# Altium schematic sheet PWR.SchDoc
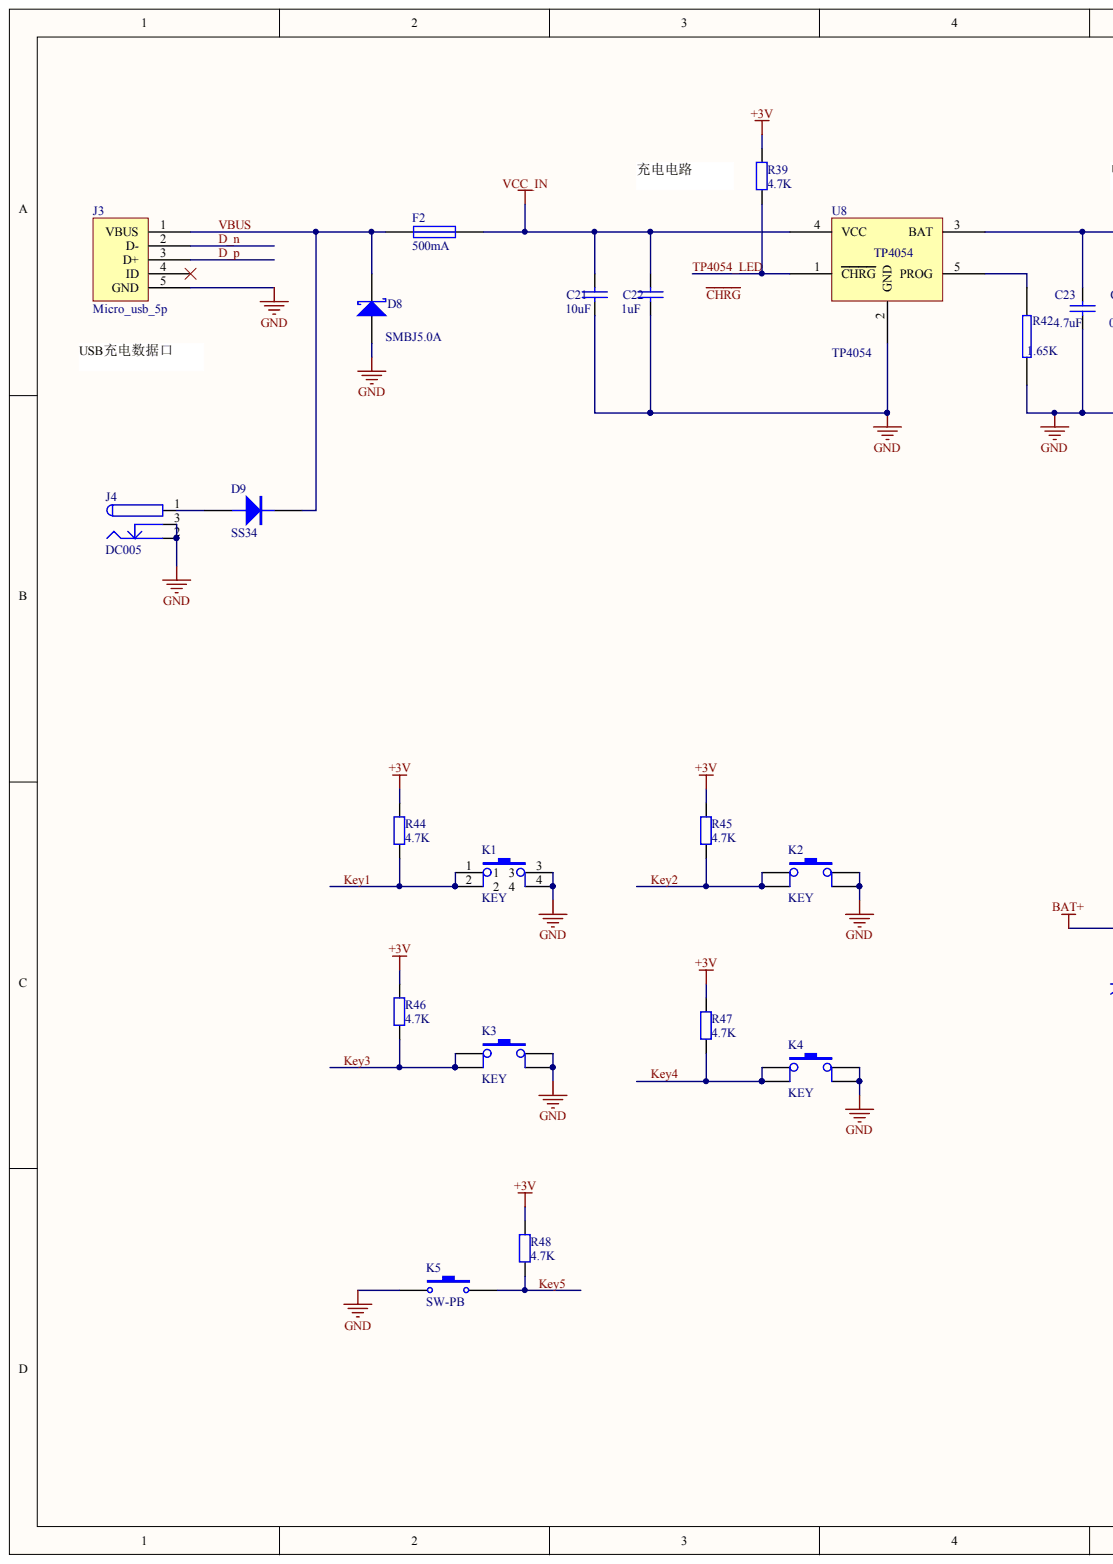
[diagram p0: PWR.SchDoc, left]
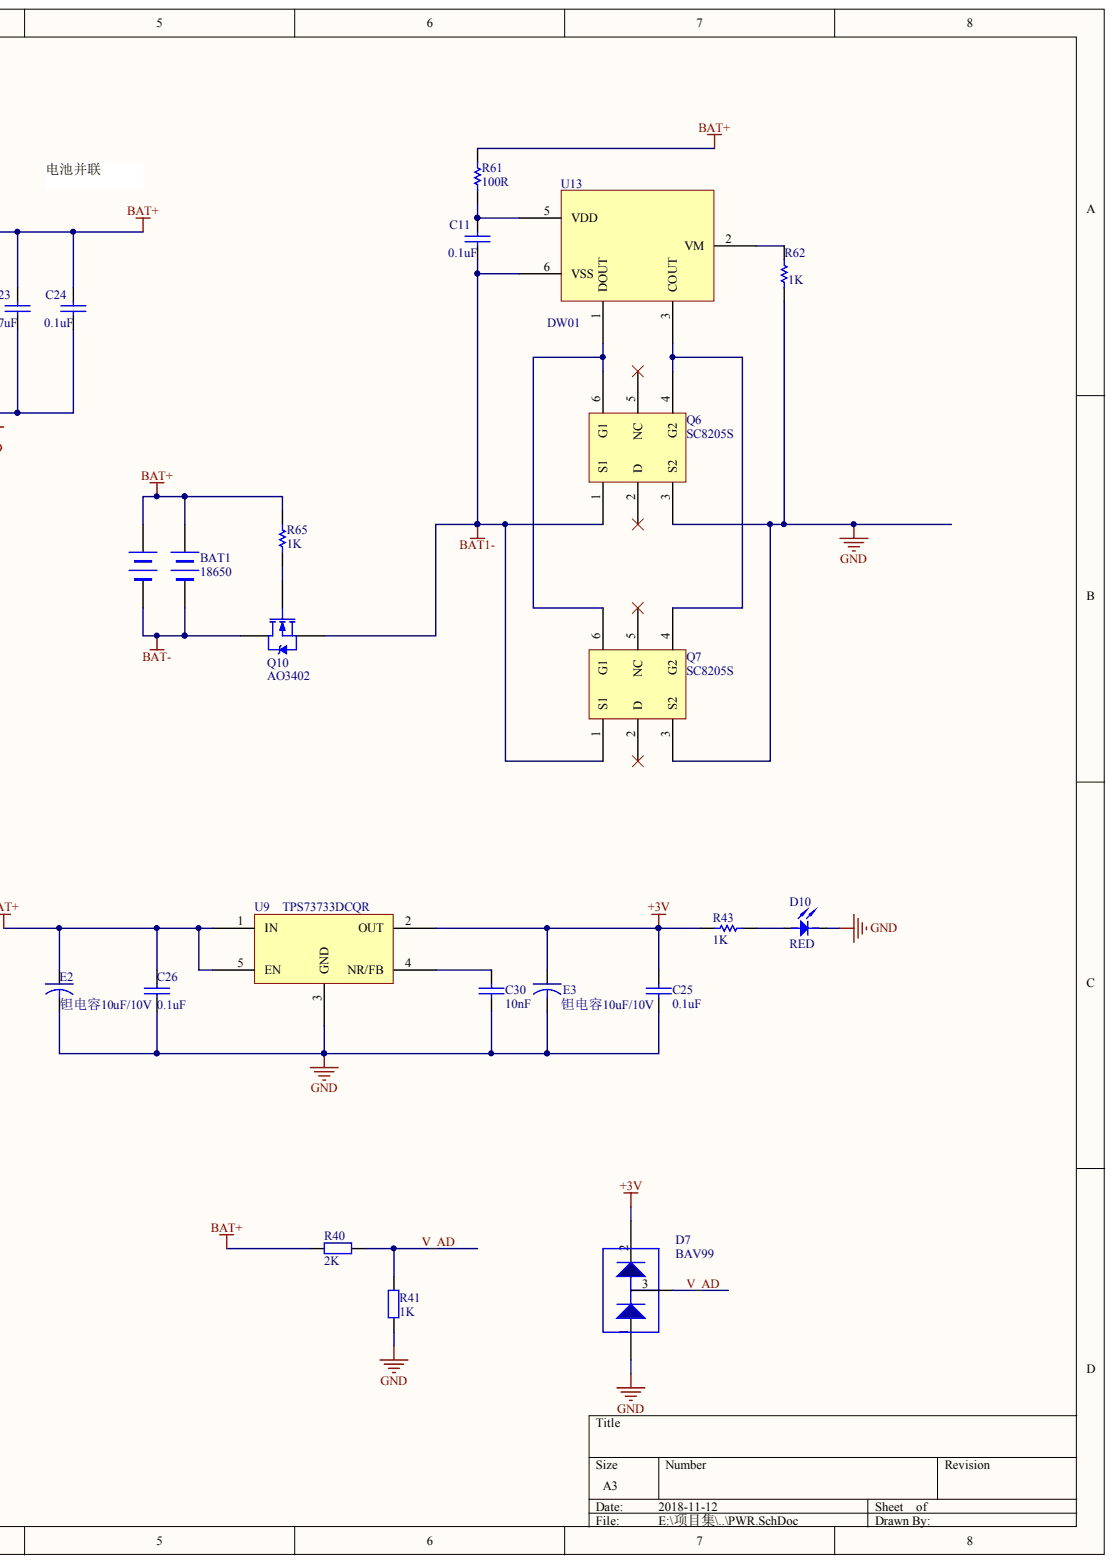
[diagram p1: PWR.SchDoc, right]

## Parts
BAT1  18650  [TANPIAN]
C11  0.1uF  [0603C]
C21  10uF  [0805C]
C22  1uF  [0603C]
C23  4.7uF  [0603C]
C24  0.1uF  [0603C]
C25  0.1uF  [0603C]
C26  0.1uF  [0603C]
C30  10nF  [0603C]
D7  BAV99  [SOT-23]
D8  SMBJ5.0A  [SMB]
D9  SS34  [SMA]
D10  RED  [FDCASE0603]
E2  钽电容10uF/10V  [3216]
E3  钽电容10uF/10V  [3216]
F2  500mA  [0805-L]
J3  Micro_usb_5p  [micro usb SH]
J4  DC005  [DC-005A]
K1  KEY  [4.5*4.5*4.3]
K2  KEY  [4.5*4.5*4.3]
K3  KEY  [4.5*4.5*4.3]
K4  KEY  [4.5*4.5*4.3]
K5  SW-PB  [C_6*6]
Q6  SC8205S  [SOT-23-6L]
Q7  SC8205S  [SOT-23-6L]
Q10  AO3402  [SOT-23]
R39  4.7K  [0603R]
R40  2K  [0603R]
R41  1K  [0603R]
R42  1.65K  [0603R]
R43  1K  [0603R]
R44  4.7K  [0603R]
R45  4.7K  [0603R]
R46  4.7K  [0603R]
R47  4.7K  [0603R]
R48  4.7K  [0603R]
R61  100R  [0603R]
R62  1K  [0603R]
R65  1K  [0603R]
U8  TP4054  [SOT-23-5L]
U9  TPS73733DCQR  [SOT223-6]
U13  DW01  [SOT-23-6L]

## Nets
+3V: C25.2 D7.2 E3.1 R39.2 R43.2 R44.2 R45.2 R46.2 R47.2 R48.2 U9.2(OUT)
BAT1-: C11.2 Q6.1(S1) Q7.1(S1) Q10.2 U13.6(VSS)
BAT+: BAT1.1 BAT1.3 C23.1 C24.1 C26.1 E2.1 R40.1 R61.1 R65.1 U8.3(BAT) U9.1(IN) U9.5(EN)
BAT-: BAT1.2 BAT1.4 Q10.3
GND: C21.2 C22.2 C23.2 C24.2 C25.1 C26.2 C30.1 D7.1 D8.1 D10.K E2.2 E3.2 J3.5(GND) J4.2 J4.3 K1.3 K1.4 K2.3 K2.4 K3.3 K3.4 K4.3 K4.4 K5.1 Q6.3(S2) Q7.3(S2) R41.1 R42.1 R62.2 U8.2(GND) U9.3(GND)
VCC_IN: C21.1 C22.1 F2.2 U8.4(VCC)
D_N: J3.2(D-)
D_P: J3.3(D+)
KEY1: K1.1 K1.2 R44.1
KEY2: K2.1 K2.2 R45.1
KEY3: K3.1 K3.2 R46.1
KEY4: K4.1 K4.2 R47.1
KEY5: K5.2 R48.1
TP4054_LED: R39.1 U8.1(CHRG)
V_AD: D7.3 R40.2 R41.2
VBUS: D8.2 D9.2 F2.1 J3.1(VBUS)
NetC11_1: C11.1 R61.2 U13.5(VDD)
NetC30_2: C30.2 U9.4(NR/FB)
NetD9_1: D9.1 J4.1
NetD10_A: D10.A R43.1
NetQ6_4: Q6.4(G2) Q7.4(G2) U13.3(COUT)
NetQ6_6: Q6.6(G1) Q7.6(G1) U13.1(DOUT)
NetQ10_1: Q10.1 R65.2
NetR42_2: R42.2 U8.5(PROG)
NetR62_1: R62.1 U13.2(VM)
~{CHRG}:

Not connected: J3 4(ID); Q6 2(D) 5(NC); Q7 2(D) 5(NC)

## Library data of the parts
BAT1 = BATTERXH1.25: Component Kind: Standard; Library Name: My Devices.SCHLIB
C11 C21-C26 C30 = CAP: Component Kind: Standard
D7 = D-DIODE: Component Kind: Standard; Library Name: My Devices.SCHLIB
D8 = D Schottky: Schottky Diode; Code_JEDEC: DO-214-AA; Component Kind: Standard; LatestRevisionDate: 17-Jul-2002; LatestRevisionNote: Re-released for DXP Platform.; Library Name: Miscellaneous Devices.IntLib; PackageReference: SMB; Published: 8-Jun-2000; Simulation: SKYDIODE; Publisher: Altium Limited
D9 = DIODE: Component Kind: Standard; Library Name: My Devices.SCHLIB
D10 = FD: Component Kind: Standard; Library Name: My Devices.SCHLIB
E2 E3 = CAPACITOR: Component Kind: Standard; Library Name: My Devices.SCHLIB
F2 = Fuse 1: Fuse; Component Kind: Standard; LatestRevisionDate: 17-Jul-2002; LatestRevisionNote: Re-released for DXP Platform.; Library Name: Miscellaneous Devices.IntLib; PackageReference: PIN-W2/E2.8; Published: 8-Jun-2000; Simulation: FUSE; Publisher: Altium Limited
J4 = PWR2: Component Kind: Standard; Library Name: My Devices.SCHLIB
K1-K4 = PB_1012: Component Kind: Standard; Library Name: My Devices.SCHLIB
K5 = SW-PB: Switch; Component Kind: Standard; LatestRevisionDate: 17-Jul-2002; LatestRevisionNote: Re-released for DXP Platform.; Library Name: Miscellaneous Devices.IntLib; PackageDescription: Switch; 2 Leads; PackageReference: SPST-2; Published: 24-Mar-1999; Publisher: Altium Limited
Q10 = NMOS: Component Kind: Standard; Library Name: My Devices.SCHLIB
R39-R41 R44-R48 = RES2: Component Kind: Standard; Library Name: My Devices.SCHLIB
R42 = RES1: Component Kind: Standard
R43 R62 R65 = Res1: Resistor; Component Kind: Standard; LatestRevisionDate: 17-Jul-2002; LatestRevisionNote: Re-released for DXP Platform.; Library Name: Miscellaneous Devices.IntLib; PackageReference: AXIAL-0.3; Published: 8-Jun-2000; Simulation: RESISTOR; Value: 1K; Publisher: Altium Limited
R61 = Res1: Resistor; Value: 1K; Component Kind: Standard; LatestRevisionDate: 17-Jul-2002; LatestRevisionNote: Re-released for DXP Platform.; Library Name: Miscellaneous Devices.IntLib; PackageReference: AXIAL-0.3; Published: 8-Jun-2000; Simulation: RESISTOR; Publisher: Altium Limited
J3 = Micro_usb_5p: Component Kind: Standard; Library Name: My Devices.SCHLIB
Q6 Q7 = SC8205S: Component Kind: Standard; Library Name: My Devices.SCHLIB
U8 = TP4054: Component Kind: Standard; Library Name: My Devices.SCHLIB
U9 = TPS73733DCQR: Component Kind: Standard; Library Name: My Devices.SCHLIB
U13 = DW01: Component Kind: Standard; Library Name: My Devices.SCHLIB
